# Altium schematic sheet Current Signal Measuring.SchDoc("Current Signal Measuring")
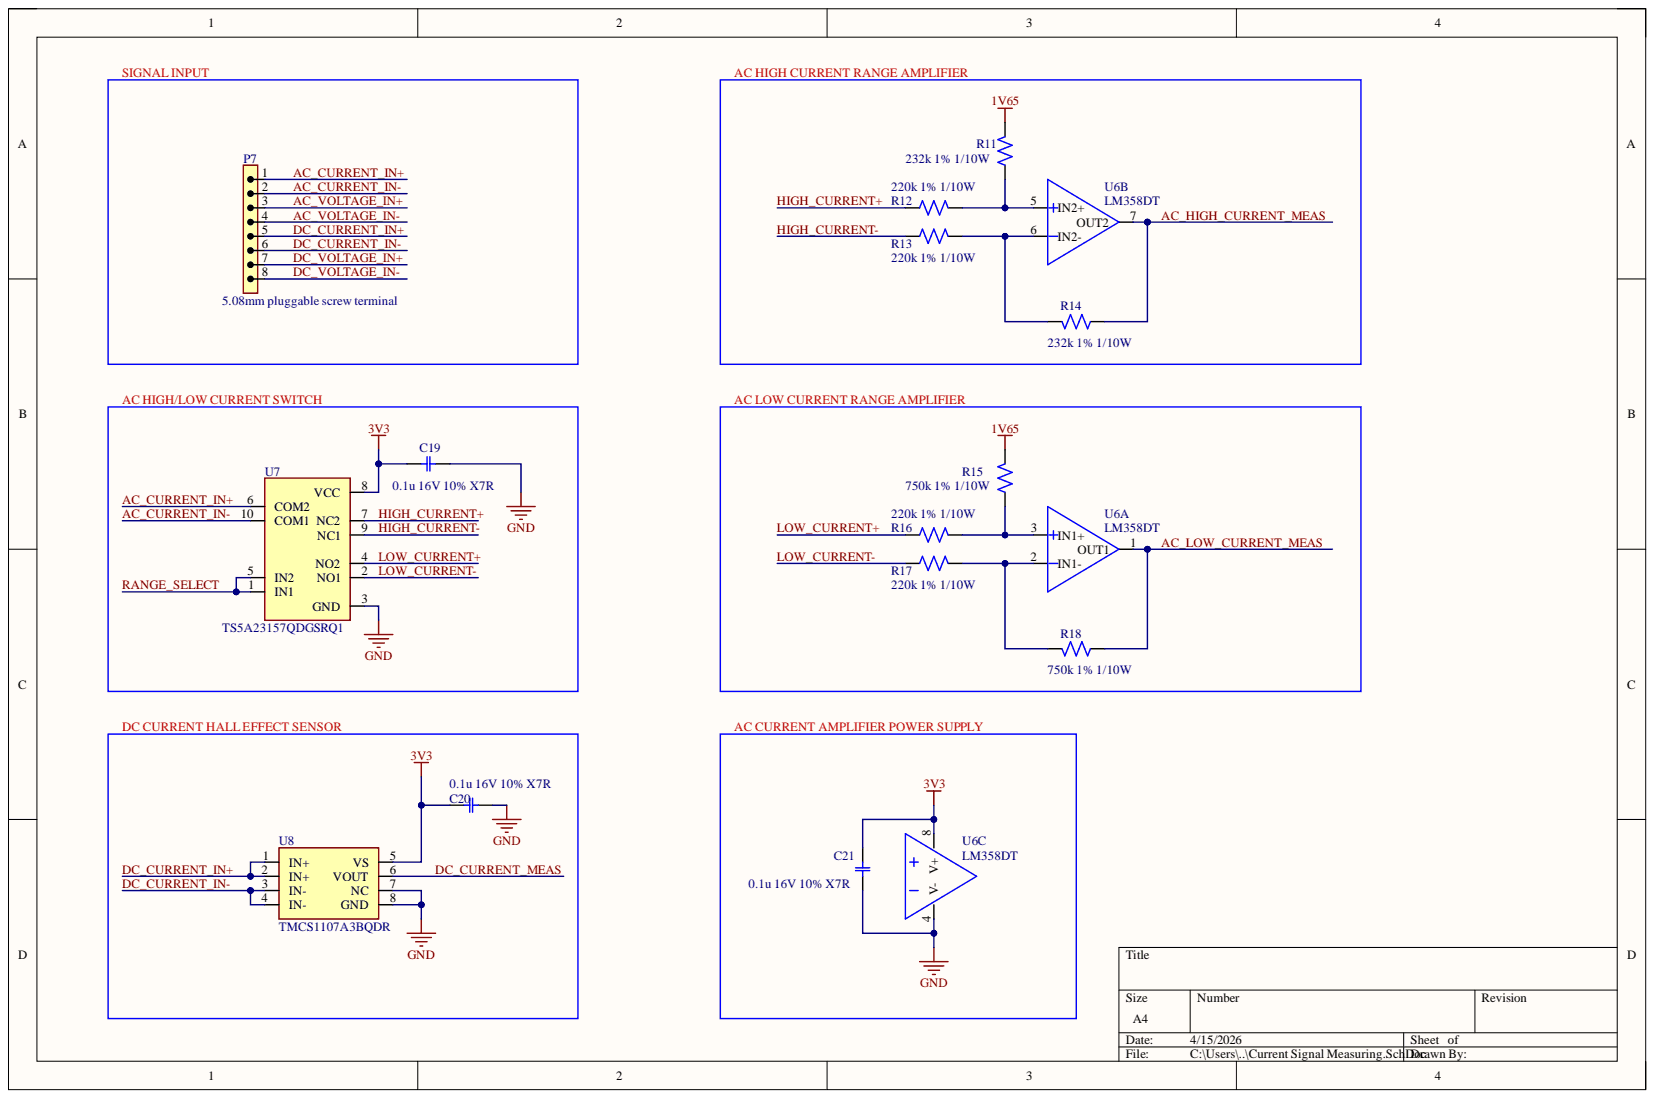
[diagram p0: Current Signal Measuring.SchDoc]

## Parts
C19  0.1u 16V 10% X7R  Dielectric: X7R  Tolerance: 10%  Voltage: 16V  [0603-C]
C20  0.1u 16V 10% X7R  Tolerance: 10%  Voltage: 16V  [0603-C]
C21  0.1u 16V 10% X7R  Dielectric: X7R  [0603-C]
P7  5.08mm pluggable screw terminal  [5.08mm pluggable screw terminal]
R11  232k 1% 1/10W  [0603-R]
R12  220k 1% 1/10W  Tolerance: 1%  [0603-R]
R13  220k 1% 1/10W  Tolerance: 1%  [0603-R]
R14  232k 1% 1/10W  Tolerance: 1%  [0603-R]
R15  750k 1% 1/10W  [0603-R]
R16  220k 1% 1/10W  Tolerance: 1%  [0603-R]
R17  220k 1% 1/10W  Tolerance: 1%  [0603-R]
R18  750k 1% 1/10W  Tolerance: 1%  [0603-R]
U6  LM358DT  [LM358DT]  (parts A,B,C)
U7  TS5A23157QDGSRQ1  [TS5A23157QDGSRQ1]
U8  TMCS1107A3BQDR  [TMCS1107A3BQDR]

## Nets
1V65: R11.1 R15.1
3V3: C19.2 C20.1 C21.1 U6.8(V+) U7.8(VCC) U8.5(VS)
GND: C19.1 C20.2 C21.2 U6.4(V-) U7.3(GND) U8.7(NC) U8.8(GND)
AC_CURRENT_IN+: P7.1 U7.6(COM2)
AC_CURRENT_IN-: P7.2 U7.10(COM1)
AC_HIGH_CURRENT_MEAS: R14.2 U6.7(OUT2)
AC_LOW_CURRENT_MEAS: R18.2 U6.1(OUT1)
AC_VOLTAGE_IN+: P7.3
AC_VOLTAGE_IN-: P7.4
DC_CURRENT_IN+: P7.5 U8.1(IN+) U8.2(IN+)
DC_CURRENT_IN-: P7.6 U8.3(IN-) U8.4(IN-)
DC_CURRENT_MEAS: U8.6(VOUT)
DC_VOLTAGE_IN+: P7.7
DC_VOLTAGE_IN-: P7.8
HIGH_CURRENT+: R12.2 U7.7(NC2)
HIGH_CURRENT-: R13.2 U7.9(NC1)
LOW_CURRENT+: R16.2 U7.4(NO2)
LOW_CURRENT-: R17.2 U7.2(NO1)
RANGE_SELECT: U7.1(IN1) U7.5(IN2)
NetR11_2: R11.2 R12.1 U6.5(IN2+)
NetR13_1: R13.1 R14.1 U6.6(IN2-)
NetR15_2: R15.2 R16.1 U6.3(IN1+)
NetR17_1: R17.1 R18.1 U6.2(IN1-)

## Library data of the parts
C19-C21 = CC0603KRX7R7BB104: 0603 0.1 uF 16 V ±10 % Tolerance X7R SMT Multilayer Ceramic Capacitor; Manufacturer: Yageo; Insulation Resistance: 100GR; Value: 100nF; Case Code (Imperial): 0603; Case Code (Metric): 1608; Case/Package: 0603; Category: Ceramic Capacitors; Component Kind: Standard; ComponentLink1Description: https://datasheet.ciiva.com/11786/0900766b80d84f1d-11786077.pdf?src-supplier=Rs; ComponentLink1URL: https://datasheet.ciiva.com/11786/0900766b80d84f1d-11786077.pdf?src-supplier=Rs; ComponentLink2Description: https://datasheet.ciiva.com/144/mlccorderingcode-144182.pdf?src-supplier=Digikey; ComponentLink2URL: https://datasheet.ciiva.com/144/mlccorderingcode-144182.pdf?src-supplier=Digikey; ComponentLink3Description: https://datasheet.ciiva.com/144/mlccorderingcode-144183.pdf?src-supplier=Digikey; ComponentLink3URL: https://datasheet.ciiva.com/144/mlccorderingcode-144183.pdf?src-supplier=Digikey; ComponentLink4Description: https://datasheet.ciiva.com/144/mlccorderingcode-144184.pdf?src-supplier=Digikey; ComponentLink4URL: https://datasheet.ciiva.com/144/mlccorderingcode-144184.pdf?src-supplier=Digikey; ComponentLink5Description: https://datasheet.ciiva.com/7978/1489800-7978141.pdf?src-supplier=Element14; ComponentLink5URL: https://datasheet.ciiva.com/7978/1489800-7978141.pdf?src-supplier=Element14; ComponentLink6Description: https://datasheet.ciiva.com/11464/upy-gphc-x7r-6-3v-to-50v-8-11464735.pdf?src-supplier=Avnet; ComponentLink6URL: https://datasheet.ciiva.com/11464/upy-gphc-x7r-6-3v-to-50v-8-11464735.pdf?src-supplier=Avnet; ComponentLink7Description: https://datasheet.ciiva.com/28450/1489800-28450552.pdf?src-supplier=Element14; ComponentLink7URL: https://datasheet.ciiva.com/28450/1489800-28450552.pdf?src-supplier=Element14; ComponentLink8Description: https://datasheet.ciiva.com/46430/1734573-46430156.pdf?src-supplier=Element14; ComponentLink8URL: https://datasheet.ciiva.com/46430/1734573-46430156.pdf?src-supplier=Element14; ComponentLink9Description: https://datasheet.ciiva.com/8033/1734573-8033797.pdf?src-supplier=Element14; ComponentLink9URL: https://datasheet.ciiva.com/8033/1734573-8033797.pdf?src-supplier=Element14; ComponentLink10Description: https://datasheet.ciiva.com/8033/70765-8033799.pdf?src-supplier=Element14; ComponentLink10URL: https://datasheet.ciiva.com/8033/70765-8033799.pdf?src-supplier=Element14; ComponentLink11Description: https://datasheet.ciiva.com/15165/getdatasheetpartid-63554-15165387.pdf?src-supplier=Verical; ComponentLink11URL: https://datasheet.ciiva.com/15165/getdatasheetpartid-63554-15165387.pdf?src-supplier=Verical; ComponentLink12Description: https://datasheet.ciiva.com/13395/upy-gphc-x7r-6-3v-to-50v-8-13395674.pdf?src-supplier=Mouser; ComponentLink12URL: https://datasheet.ciiva.com/13395/upy-gphc-x7r-6-3v-to-50v-8-13395674.pdf?src-supplier=Mouser; Depth: 0.8mm; Halogen Free: Halogen Free; Height: 0.8mm; Lead Free: Lead Free; Length: 1.6mm; Manufacturer Part Number: CC0603KRX7R7BB104; Material: Ceramic; Max Operating Temperature: 125°C; Min Operating Temperature: -55°C; Mounting Technology: Surface Mount; Package Quantity: 1; Packaging: Tape and Reel; Pins: 2; Pricing: 1=0.08, 10=0.014, 50=0.012, 100=0.0096, 500=0.0072, 1000=0.0064 (USD); Radiation Hardening: No; Reach SVHC Compliant: No; RoHS: Yes; RoHS Compliant: Yes; Series: CC; Stock: 7111175; Supplier 1: Digikey; Supplier Part Number 1: 311-1088-1-ND; Termination: SMD/SMT; Thickness: 0.035inch; Voltage Rating: 16V; Voltage Rating (DC): 16V; Width: 0.031inch; Library Name: tpmania.SchLib
  C20: Dielectric: X7R
  C21: Tolerance: 10%; Voltage: 16V
R11 R14 = RC0603FR-07232KL: 100mW Thick Film Resistors 75V ±100ppm/℃ ±1% 232kΩ 0603 Chip Resistor - Surface Mount ROHS; Manufacturer: Yageo Group; Temperature Coefficient: 100ppm/°C; Voltage Rating (DC): 50V; Case Code (Imperial): 0603; Case/Package: 0603; Category: Chip SMD Resistors; China RoHS: Non-Compliant; Component Kind: Standard; ComponentLink1Description: https://datasheet.octopart.com:443/RC0603FR-07232KL-Yageo-datasheet-175887523.pdf?src-supplier=IHS; ComponentLink1URL: https://datasheet.octopart.com:443/RC0603FR-07232KL-Yageo-datasheet-175887523.pdf?src-supplier=IHS; ComponentLink2Description: https://datasheet.octopart.com:443/RC0603FR-07232KL-Yageo-datasheet-103092701.pdf?src-supplier=Upverter; ComponentLink2URL: https://datasheet.octopart.com:443/RC0603FR-07232KL-Yageo-datasheet-103092701.pdf?src-supplier=Upverter; ComponentLink3Description: https://datasheet.octopart.com:443/RC0603FR-07232KL-Yageo-Group-datasheet-189192902.pdf?src-supplier=TME; ComponentLink3URL: https://datasheet.octopart.com:443/RC0603FR-07232KL-Yageo-Group-datasheet-189192902.pdf?src-supplier=TME; ComponentLink4Description: https://datasheet.octopart.com:443/RC0603FR-07232KL-Yageo-Group-datasheet-176638170.pdf?src-supplier=Future+Electronics; ComponentLink4URL: https://datasheet.octopart.com:443/RC0603FR-07232KL-Yageo-Group-datasheet-176638170.pdf?src-supplier=Future+Electronics; ComponentLink5Description: https://datasheet.octopart.com:443/RC0603FR-07232KL-Yageo-datasheet-164759695.pdf?src-supplier=Future+Electronics; ComponentLink5URL: https://datasheet.octopart.com:443/RC0603FR-07232KL-Yageo-datasheet-164759695.pdf?src-supplier=Future+Electronics; ComponentLink6Description: https://datasheet.octopart.com:443/RC0603FR-07232KL-Yageo-datasheet-137700087.pdf?src-supplier=Future+Electronics; ComponentLink6URL: https://datasheet.octopart.com:443/RC0603FR-07232KL-Yageo-datasheet-137700087.pdf?src-supplier=Future+Electronics; ComponentLink7Description: https://datasheet.octopart.com:443/RC0603FR-07232KL-Yageo-datasheet-17842380.pdf?src-supplier=Future+Electronics; ComponentLink7URL: https://datasheet.octopart.com:443/RC0603FR-07232KL-Yageo-datasheet-17842380.pdf?src-supplier=Future+Electronics; ComponentLink8Description: https://datasheet.octopart.com:443/RC0603FR-07232KL-Yageo-datasheet-15599030.pdf?src-supplier=Future+Electronics; ComponentLink8URL: https://datasheet.octopart.com:443/RC0603FR-07232KL-Yageo-datasheet-15599030.pdf?src-supplier=Future+Electronics; ComponentLink9Description: https://datasheet.octopart.com:443/RC0603FR-07232KL-Yageo-datasheet-12596169.pdf?src-supplier=Future+Electronics; ComponentLink9URL: https://datasheet.octopart.com:443/RC0603FR-07232KL-Yageo-datasheet-12596169.pdf?src-supplier=Future+Electronics; ComponentLink10Description: https://datasheet.octopart.com:443/RC0603FR-07232KL-Yageo-datasheet-8328172.pdf?src-supplier=_legacy+Avnet; ComponentLink10URL: https://datasheet.octopart.com:443/RC0603FR-07232KL-Yageo-datasheet-8328172.pdf?src-supplier=_legacy+Avnet; ComponentLink11Description: https://datasheet.octopart.com/RC0603FR-07232KL-Yageo-datasheet-73766026.pdf; ComponentLink11URL: https://datasheet.octopart.com/RC0603FR-07232KL-Yageo-datasheet-73766026.pdf; ComponentLink12Description: https://datasheet.octopart.com:443/RC0603FR-07232KL-Yageo-datasheet-118562.pdf?src-supplier=DigiKey; ComponentLink12URL: https://datasheet.octopart.com:443/RC0603FR-07232KL-Yageo-datasheet-118562.pdf?src-supplier=DigiKey; ComponentLink13Description: http://sigma.octopart.com:80/conflict_mineral_statement/Yageo-company-3.pdf?src-supplier=Compliance+Statements; ComponentLink13URL: http://sigma.octopart.com:80/conflict_mineral_statement/Yageo-company-3.pdf?src-supplier=Compliance+Statements; ComponentLink14Description: http://sigma.octopart.com:80/rohs_statement/Yageo-company-2.pdf?src-supplier=Compliance+Statements; ComponentLink14URL: http://sigma.octopart.com:80/rohs_statement/Yageo-company-2.pdf?src-supplier=Compliance+Statements; Composition: Thick Film; Contact Plating: Tin; Country of Origin: Mainland China, Taiwan; Depth: 800µm; Export Control Classification Number (ECCN) Code: EAR99; Features: Moisture Resistant; Harmonized Tariff Schedule (HTS) Code: 8533.21.00.30; Height: 450µm; Introduction Date: 2004-09-22; Lead Free: Lead Free; Length: 1.6mm; Library Name: ENGG3800 schematic library.SchLib; Lifecycle Status: Production; Manufacturer Part Number: RC0603FR-07232KL; Max Operating Temperature: 155°C; Max Power Dissipation: 100mW; Military Standard: Not; Min Operating Temperature: -55°C; Mounting Technology: Surface Mount; Number of Terminals: 2; Number of Terminations: 2; Packaging: Tape & Reel; Power Dissipation: 100mW; Power Rating: 100mW; Pricing: 1=0.103, 25=0.007, 100=0.006 (USD); REACH SVHC: Yes; RoHS: Compliant; Schedule B: 8533210045, 8533210045|8533210045, 8533210045|8533210045|8533210045, 8533210045|8533210045|8533210045|8533210045; Stock: 2291; Supplier 1: Digikey; Supplier Part Number 1: 311-232KHRCT-ND; Termination: SMD/SMT; Value: 232kΩ; Voltage Rating: 75V; Width: 800µm; Working Voltage: 75V
  R11: Tolerance: 1%
R12 R13 R16 R17 = RMCF0603FT220K: Res Thick Film 0603 220K Ohm 1% 0.1W(1/10W) ±100ppm/C Pad SMD Automotive T/R; Manufacturer: Stackpole Electronics; Max Power Dissipation: 100mW; Temperature Coefficient: 100ppm/°C; Value: 220kΩ; Voltage Rating: 75V; Case Code (Imperial): 0603; Case Code (Metric): 1608; Case/Package: 0603; Category: Chip SMD Resistors; China RoHS: Non-Compliant; Component Kind: Standard; ComponentLink1Description: https://datasheet.octopart.com:443/RMCF0603FT220K-Stackpole-Electronics-datasheet-182148065.pdf?src-supplier=IHS; ComponentLink1URL: https://datasheet.octopart.com:443/RMCF0603FT220K-Stackpole-Electronics-datasheet-182148065.pdf?src-supplier=IHS; ComponentLink2Description: https://datasheet.octopart.com:443/RMCF0603FT220K-Stackpole-Electronics-datasheet-28504820.pdf?src-supplier=Upverter; ComponentLink2URL: https://datasheet.octopart.com:443/RMCF0603FT220K-Stackpole-Electronics-datasheet-28504820.pdf?src-supplier=Upverter; ComponentLink3Description: https://datasheet.octopart.com:443/RMCF0603FT220K-Stackpole-Electronics-datasheet-141193804.pdf?src-supplier=Stackpole+Electronics; ComponentLink3URL: https://datasheet.octopart.com:443/RMCF0603FT220K-Stackpole-Electronics-datasheet-141193804.pdf?src-supplier=Stackpole+Electronics; ComponentLink4Description: https://datasheet.octopart.com:443/RMCF0603FT220K-Stackpole-Electronics-datasheet-62091608.pdf?src-supplier=Stackpole+Electronics; ComponentLink4URL: https://datasheet.octopart.com:443/RMCF0603FT220K-Stackpole-Electronics-datasheet-62091608.pdf?src-supplier=Stackpole+Electronics; ComponentLink5Description: https://datasheet.octopart.com:443/RMCF0603FT220K-Stackpole-Electronics-datasheet-12534581.pdf?src-supplier=Stackpole+Electronics; ComponentLink5URL: https://datasheet.octopart.com:443/RMCF0603FT220K-Stackpole-Electronics-datasheet-12534581.pdf?src-supplier=Stackpole+Electronics; ComponentLink6Description: https://datasheet.octopart.com:443/RMCF0603FT220K-Stackpole-Electronics-datasheet-10788263.pdf?src-supplier=Stackpole+Electronics; ComponentLink6URL: https://datasheet.octopart.com:443/RMCF0603FT220K-Stackpole-Electronics-datasheet-10788263.pdf?src-supplier=Stackpole+Electronics; ComponentLink7Description: http://sigma.octopart.com:80/15940719/materials_sheet/Stackpole-Electronics-RMCF0603FT220K.pdf?src-supplier=Compliance+Statements; ComponentLink7URL: http://sigma.octopart.com:80/15940719/materials_sheet/Stackpole-Electronics-RMCF0603FT220K.pdf?src-supplier=Compliance+Statements; ComponentLink8Description: http://sigma.octopart.com:80/141158211/reach_statement/Stackpole-Electronics-RMCF0603FT220K.pdf?src-supplier=Compliance+Statements; ComponentLink8URL: http://sigma.octopart.com:80/141158211/reach_statement/Stackpole-Electronics-RMCF0603FT220K.pdf?src-supplier=Compliance+Statements; ComponentLink9Description: http://sigma.octopart.com:80/141158212/rohs_statement/Stackpole-Electronics-RMCF0603FT220K.pdf?src-supplier=Compliance+Statements; ComponentLink9URL: http://sigma.octopart.com:80/141158212/rohs_statement/Stackpole-Electronics-RMCF0603FT220K.pdf?src-supplier=Compliance+Statements; Composition: Thick Film; Contact Plating: Tin; Depth: 800µm; Features: Automotive AEC-Q200; Height: 450µm; Lead Free: Lead Free; Length: 1.55mm; Library Name: ENGG3800 schematic library.SchLib; Lifecycle Status: Production; Manufacturer Part Number: RMCF0603FT220K; Max Operating Temperature: 155°C; Military Standard: Not; Min Operating Temperature: -55°C; Mounting Technology: Surface Mount; Number of Terminals: 2; Number of Terminations: 2; Packaging: Tape & Reel (TR); Power Dissipation: 100mW; Power Rating: 100mW; Pricing: 5000=0.0019, 10000=0.00188, 20000=0.00185, 40000=0.00182, 80000=0.00179 (USD); Radiation Hardening: No; REACH SVHC: Yes; RoHS: Compliant; Schedule B: 8533210045, 8533210045|8533210045, 8533210045|8533210045|8533210045, 8533210045|8533210045|8533210045|8533210045; Stock: 385000; Supplier 1: Digikey; Supplier Part Number 1: RMCF0603FT220KCT-ND; Width: 800µm; Working Voltage: 75V
R15 R18 = RC0603FR-07750KL: 100mW Thick Film Resistors 75V ±100ppm/℃ ±1% 750kΩ 0603 Chip Resistor - Surface Mount ROHS; Manufacturer: Yageo Group; Temperature Coefficient: 100ppm/°C; Voltage Rating (DC): 50V; Case Code (Imperial): 0603; Case/Package: 0603; Category: Chip SMD Resistors; China RoHS: Non-Compliant; Component Kind: Standard; ComponentLink1Description: https://datasheet.octopart.com:443/RC0603FR-07750KL-Yageo-datasheet-175887523.pdf?src-supplier=Newark; ComponentLink1URL: https://datasheet.octopart.com:443/RC0603FR-07750KL-Yageo-datasheet-175887523.pdf?src-supplier=Newark; ComponentLink2Description: https://datasheet.octopart.com:443/RC0603FR-07750KL-Yageo-datasheet-103092701.pdf?src-supplier=Upverter; ComponentLink2URL: https://datasheet.octopart.com:443/RC0603FR-07750KL-Yageo-datasheet-103092701.pdf?src-supplier=Upverter; ComponentLink3Description: https://datasheet.octopart.com:443/RC0603FR-07750KL-Yageo-Group-datasheet-176638170.pdf?src-supplier=Future+Electronics; ComponentLink3URL: https://datasheet.octopart.com:443/RC0603FR-07750KL-Yageo-Group-datasheet-176638170.pdf?src-supplier=Future+Electronics; ComponentLink4Description: https://datasheet.octopart.com:443/RC0603FR-07750KL-Yageo-datasheet-164759695.pdf?src-supplier=Future+Electronics; ComponentLink4URL: https://datasheet.octopart.com:443/RC0603FR-07750KL-Yageo-datasheet-164759695.pdf?src-supplier=Future+Electronics; ComponentLink5Description: https://datasheet.octopart.com:443/RC0603FR-07750KL-Yageo-datasheet-137700087.pdf?src-supplier=Future+Electronics; ComponentLink5URL: https://datasheet.octopart.com:443/RC0603FR-07750KL-Yageo-datasheet-137700087.pdf?src-supplier=Future+Electronics; ComponentLink6Description: https://datasheet.octopart.com:443/RC0603FR-07750KL-Yageo-datasheet-15599030.pdf?src-supplier=Future+Electronics; ComponentLink6URL: https://datasheet.octopart.com:443/RC0603FR-07750KL-Yageo-datasheet-15599030.pdf?src-supplier=Future+Electronics; ComponentLink7Description: https://datasheet.octopart.com:443/RC0603FR-07750KL-Yageo-datasheet-12596169.pdf?src-supplier=Future+Electronics; ComponentLink7URL: https://datasheet.octopart.com:443/RC0603FR-07750KL-Yageo-datasheet-12596169.pdf?src-supplier=Future+Electronics; ComponentLink8Description: https://datasheet.octopart.com:443/RC0603FR-07750KL-Yageo-datasheet-8328172.pdf?src-supplier=Future+Electronics; ComponentLink8URL: https://datasheet.octopart.com:443/RC0603FR-07750KL-Yageo-datasheet-8328172.pdf?src-supplier=Future+Electronics; ComponentLink9Description: https://datasheet.octopart.com:443/RC0603FR-07750KL-Yageo-datasheet-148775077.pdf?src-supplier=TME; ComponentLink9URL: https://datasheet.octopart.com:443/RC0603FR-07750KL-Yageo-datasheet-148775077.pdf?src-supplier=TME; ComponentLink10Description: https://datasheet.octopart.com:443/RC0603FR-07750KL-Yageo-datasheet-79472498.pdf?src-supplier=Megastar; ComponentLink10URL: https://datasheet.octopart.com:443/RC0603FR-07750KL-Yageo-datasheet-79472498.pdf?src-supplier=Megastar; ComponentLink11Description: https://datasheet.octopart.com/RC0603FR-07750KL-Yageo-datasheet-73766026.pdf; ComponentLink11URL: https://datasheet.octopart.com/RC0603FR-07750KL-Yageo-datasheet-73766026.pdf; ComponentLink12Description: https://datasheet.octopart.com:443/RC0603FR-07750KL-Yageo-datasheet-118562.pdf?src-supplier=DigiKey; ComponentLink12URL: https://datasheet.octopart.com:443/RC0603FR-07750KL-Yageo-datasheet-118562.pdf?src-supplier=DigiKey; ComponentLink13Description: http://sigma.octopart.com:80/conflict_mineral_statement/Yageo-company-3.pdf?src-supplier=Compliance+Statements; ComponentLink13URL: http://sigma.octopart.com:80/conflict_mineral_statement/Yageo-company-3.pdf?src-supplier=Compliance+Statements; ComponentLink14Description: http://sigma.octopart.com:80/rohs_statement/Yageo-company-2.pdf?src-supplier=Compliance+Statements; ComponentLink14URL: http://sigma.octopart.com:80/rohs_statement/Yageo-company-2.pdf?src-supplier=Compliance+Statements; Composition: Thick Film; Depth: 800µm; Features: Moisture Resistant; Height: 450µm; Lead Free: Lead Free; Length: 1.6mm; Library Name: ENGG3800 schematic library.SchLib; Lifecycle Status: Production; Manufacturer Part Number: RC0603FR-07750KL; Max Operating Temperature: 155°C; Max Power Dissipation: 100mW; Military Standard: Not; Min Operating Temperature: -55°C; Mounting Technology: Surface Mount; Number of Terminals: 2; Number of Terminations: 2; Packaging: Tape & Reel; Power Dissipation: 100mW; Power Rating: 100mW; Pricing: 1=0.103, 25=0.008, 50=0.007, 100=0.006 (USD); REACH SVHC: Yes; RoHS: Compliant; Schedule B: 8533210045, 8533210045|8533210045, 8533210045|8533210045|8533210045, 8533210045|8533210045|8533210045|8533210045; Stock: 11686; Supplier 1: Digikey; Supplier Part Number 1: 311-750KHRCT-ND; Termination: SMD/SMT; Value: 750kΩ; Voltage Rating: 75V; Weight: 2.012816mg; Width: 800µm; Working Voltage: 75V
  R15: Tolerance: 1%
U6 = LM358DT: Integrated Circuit Operational Amplifier; CH: 2; 1.1MHz; 3-32V; 0+70 deg.C; SMD; SO8; Manufacturer: STMicroelectronics; Common Mode Rejection Ratio: 60dB; Dual Supply Voltage: 9V; Input Bias Current: 150nA; Input Offset Voltage (Vos): 9mV; Max Dual Supply Voltage: 15V; Max Junction Temperature (Tj): 150°C; Max Output current: 60mA; Max Supply Current: 2mA; Max Supply Voltage: 28.5V; Min Dual Supply Voltage: 1.5V; Min Input Voltage: 0V; Min Supply Voltage: 3V; Nominal Supply Current: 350µA; Number of Amplifiers: 2; Operating Supply Current: 700µA; Operating Supply Voltage: 5V; Slew Rate: 0.3V/µs; Voltage Gain: 100dB; Bandwidth: 1.1MHz; Case/Package: SOP; Category: Amplifiers - Op Amps, Buffer, Instrumentation; China RoHS: Compliant; Component Kind: Standard; ComponentLink1Description: https://datasheet.octopart.com:443/LM358DT-STMicroelectronics-datasheet-116606904.pdf?src-supplier=ODG+(Origin+Data+Global); ComponentLink1URL: https://datasheet.octopart.com:443/LM358DT-STMicroelectronics-datasheet-116606904.pdf?src-supplier=ODG+(Origin+Data+Global); ComponentLink2Description: https://datasheet.octopart.com:443/LM358DT-STMicroelectronics-datasheet-61210252.pdf?src-supplier=element14+APAC; ComponentLink2URL: https://datasheet.octopart.com:443/LM358DT-STMicroelectronics-datasheet-61210252.pdf?src-supplier=element14+APAC; ComponentLink3Description: https://datasheet.octopart.com:443/LM358DT-STMicroelectronics-datasheet-11005313.pdf?src-supplier=STMicroelectronics; ComponentLink3URL: https://datasheet.octopart.com:443/LM358DT-STMicroelectronics-datasheet-11005313.pdf?src-supplier=STMicroelectronics; ComponentLink4Description: https://datasheet.octopart.com:443/LM358DT-STMicroelectronics-datasheet-10410245.pdf?src-supplier=Future+Electronics; ComponentLink4URL: https://datasheet.octopart.com:443/LM358DT-STMicroelectronics-datasheet-10410245.pdf?src-supplier=Future+Electronics; ComponentLink5Description: https://datasheet.octopart.com:443/LM358DT-STMicroelectronics-datasheet-529748.pdf?src-supplier=element14; ComponentLink5URL: https://datasheet.octopart.com:443/LM358DT-STMicroelectronics-datasheet-529748.pdf?src-supplier=element14; ComponentLink6Description: https://datasheet.octopart.com:443/LM358DT-STMicroelectronics-datasheet-109535.pdf?src-supplier=element14; ComponentLink6URL: https://datasheet.octopart.com:443/LM358DT-STMicroelectronics-datasheet-109535.pdf?src-supplier=element14; ComponentLink7Description: https://datasheet.octopart.com:443/LM358DT-STMicroelectronics-datasheet-81668593.pdf?src-supplier=SHENGYU+ELECTRONICS; ComponentLink7URL: https://datasheet.octopart.com:443/LM358DT-STMicroelectronics-datasheet-81668593.pdf?src-supplier=SHENGYU+ELECTRONICS; ComponentLink8Description: https://datasheet.octopart.com:443/LM358DT-STMicroelectronics-datasheet-36993016.pdf?src-supplier=TME; ComponentLink8URL: https://datasheet.octopart.com:443/LM358DT-STMicroelectronics-datasheet-36993016.pdf?src-supplier=TME; ComponentLink9Description: https://datasheet.octopart.com:443/LM358DT-STMicroelectronics-datasheet-57.pdf?src-supplier=Newark; ComponentLink9URL: https://datasheet.octopart.com:443/LM358DT-STMicroelectronics-datasheet-57.pdf?src-supplier=Newark; ComponentLink10Description: https://datasheet.octopart.com:443/LM358DT-STMicroelectronics-datasheet-15994790.pdf?src-supplier=Newark; ComponentLink10URL: https://datasheet.octopart.com:443/LM358DT-STMicroelectronics-datasheet-15994790.pdf?src-supplier=Newark; ComponentLink11Description: https://datasheet.octopart.com:443/LM358DT-STMicroelectronics-datasheet-14137276.pdf?src-supplier=iiiC; ComponentLink11URL: https://datasheet.octopart.com:443/LM358DT-STMicroelectronics-datasheet-14137276.pdf?src-supplier=iiiC; ComponentLink12Description: https://datasheet.octopart.com:443/LM358DT-STMicroelectronics-datasheet-9802834.pdf?src-supplier=RS+(Formerly+Allied+Electronics); ComponentLink12URL: https://datasheet.octopart.com:443/LM358DT-STMicroelectronics-datasheet-9802834.pdf?src-supplier=RS+(Formerly+Allied+Electronics); ComponentLink13Description: https://datasheet.octopart.com:443/LM358DT-STMicroelectronics-datasheet-5352292.pdf?src-supplier=Farnell; ComponentLink13URL: https://datasheet.octopart.com:443/LM358DT-STMicroelectronics-datasheet-5352292.pdf?src-supplier=Farnell; Contact Plating: Tin; Country of Origin: Mainland China; Export Control Classification Number (ECCN) Code: EAR99; Gain Bandwidth Product: 1.1MHz; Harmonized Tariff Schedule (HTS) Code: 8542.33.00.01; Height: 1.75mm; Height - Seated (Max): 1.75mm; Introduction Date: 1980-01-04; Lead Free: Lead Free; Length: 4.9mm; Library Name: ENGG3800 schematic library.SchLib; Lifecycle Status: Production; Manufacturer Part Number: LM358DT; Max Operating Temperature: 70°C; Min Operating Temperature: 0°C; Mounting Technology: Surface Mount; Number of Channels: 2; Number of Circuits: 2; Number of Elements: 2; Number of Terminals: 2; Output Current: 60mA; Output Current per Channel: 60mA; Packaging: Tape and Reel; Pins: 8; Pricing: 2500=0.0538, 5000=0.05346, 10000=0.05247, 20000=0.05152, 40000=0.0506 (USD); Radiation Hardening: No; REACH SVHC: Yes; RoHS: Compliant; Schedule B: 8542330000, 8542330000|8542330000|8542330000|8542330000|8542330000|8542330000|8542330000|8542330000|8542330000|8542330000|8542330000|8542330000|8542330000|8542330000|8542330000|8542330000|8542330000|8542330000|8542330000|8542330000|8542330000|8542330000|8542330000|85; Stock: 97500; Supplier 1: Digikey; Supplier Part Number 1: 497-1591-1-ND; Temperature Grade: Commercial; Terminal Pitch: 1.27mm; Unity Gain Bandwidth Product: 1.1MHz; Weight: 4.535924g; Width: 3.9mm
U7 = TS5A23157QDGSRQ1: Automotive 5-V, 2: 1 (SPDT), 2-channel analog switch 10-VSSOP -40 to 125; Manufacturer: Texas Instruments; Manufacturer Lifecycle Status: ACTIVE; -3db Bandwidth: 220MHz; Drain to Source Resistance: 15Ω; High Level Output Current: 50mA; Max Supply Current: 1µA; Max Supply Voltage: 5.5V; Min Supply Voltage: 1.65V; Number of Channels: 2; Number of Outputs: 4; Polarity: Non-Inverting; Supply Type: Single; Turn-Off Delay Time: 3.8ns; Turn-On Delay Time: 57ns; Ambient Temperature Range High: 125°C; Bandwidth: 220MHz; Case/Package: VSSOP; Category: Analog Switches and Multiplexers; Component Kind: Standard; ComponentLink1Description: https://octopart.com:443/opatz8j6/c1?t=6YZ1PmnTPIkj37n7SMi41KU_OXrygvbwysh-KHZV3QdO04vf07P6rMInUELX-LiUtAzRPKGQT_JvMPLneT9eIBN29fDZZ10qik4sgMec-Q6GAEp21w4HXnwO5StuOSALNDe0I3OwF59_wb6A1UNdlpKbmY8Xur33UpllzqyqEJfBCNEuhlTFPE7_3sAFeDb8k_baysLsZjo5WsllNZkc75ea8NW0Zz69yhqmbjUwzPgz0CVeyVEs_g4emFC0g84vbaUs_u0WJWlufSmOLijUpaLnH-EyrG3UBaX4S_DiOQi45LT66-up3whHCSR3fk4kHG8DgoDtImjX4ZpukeCQEncWW2nOZ4Avl5mlWYcHG1wpW6iaLR269OfnVA00RmD2L0qyzxNDK_l9&src-supplier=Texas+Instruments; ComponentLink1URL: https://octopart.com:443/opatz8j6/c1?t=6YZ1PmnTPIkj37n7SMi41KU_OXrygvbwysh-KHZV3QdO04vf07P6rMInUELX-LiUtAzRPKGQT_JvMPLneT9eIBN29fDZZ10qik4sgMec-Q6GAEp21w4HXnwO5StuOSALNDe0I3OwF59_wb6A1UNdlpKbmY8Xur33UpllzqyqEJfBCNEuhlTFPE7_3sAFeDb8k_baysLsZjo5WsllNZkc75ea8NW0Zz69yhqmbjUwzPgz0CVeyVEs_g4emFC0g84vbaUs_u0WJWlufSmOLijUpaLnH-EyrG3UBaX4S_DiOQi45LT66-up3whHCSR3fk4kHG8DgoDtImjX4ZpukeCQEncWW2nOZ4Avl5mlWYcHG1wpW6iaLR269OfnVA00RmD2L0qyzxNDK_l9&src-supplier=Texas+Instruments; ComponentLink2Description: https://datasheet.octopart.com:443/TS5A23157QDGSRQ1-Texas-Instruments-datasheet-14263184.pdf?src-supplier=Texas+Instruments; ComponentLink2URL: https://datasheet.octopart.com:443/TS5A23157QDGSRQ1-Texas-Instruments-datasheet-14263184.pdf?src-supplier=Texas+Instruments; ComponentLink3Description: https://sigma.octopart.com:443/49250172/technical_drawing/Texas-Instruments-TS5A23157QDGSRQ1.pdf?src-supplier=Texas+Instruments; ComponentLink3URL: https://sigma.octopart.com:443/49250172/technical_drawing/Texas-Instruments-TS5A23157QDGSRQ1.pdf?src-supplier=Texas+Instruments; ComponentLink4Description: https://datasheet.octopart.com:443/TS5A23157QDGSRQ1-Texas-Instruments-datasheet-150511284.pdf?src-supplier=Upverter; ComponentLink4URL: https://datasheet.octopart.com:443/TS5A23157QDGSRQ1-Texas-Instruments-datasheet-150511284.pdf?src-supplier=Upverter; ComponentLink5Description: https://datasheet.octopart.com:443/TS5A23157QDGSRQ1-Texas-Instruments-datasheet-15846683.pdf?src-supplier=element14+APAC; ComponentLink5URL: https://datasheet.octopart.com:443/TS5A23157QDGSRQ1-Texas-Instruments-datasheet-15846683.pdf?src-supplier=element14+APAC; ComponentLink6Description: https://datasheet.octopart.com:443/TS5A23157QDGSRQ1-Texas-Instruments-datasheet-159118349.pdf?src-supplier=LCSC; ComponentLink6URL: https://datasheet.octopart.com:443/TS5A23157QDGSRQ1-Texas-Instruments-datasheet-159118349.pdf?src-supplier=LCSC; ComponentLink7Description: https://datasheet.octopart.com:443/TS5A23157QDGSRQ1-Texas-Instruments-datasheet-164421065.pdf?src-supplier=SHENGYU+ELECTRONICS; ComponentLink7URL: https://datasheet.octopart.com:443/TS5A23157QDGSRQ1-Texas-Instruments-datasheet-164421065.pdf?src-supplier=SHENGYU+ELECTRONICS; ComponentLink8Description: http://sigma.octopart.com:80/reach_statement/Texas-Instruments-company-64.pdf?src-supplier=Compliance+Statements; ComponentLink8URL: http://sigma.octopart.com:80/reach_statement/Texas-Instruments-company-64.pdf?src-supplier=Compliance+Statements; ComponentLink9Description: http://sigma.octopart.com:80/conflict_mineral_statement/Texas-Instruments-company-63.pdf?src-supplier=Compliance+Statements; ComponentLink9URL: http://sigma.octopart.com:80/conflict_mineral_statement/Texas-Instruments-company-63.pdf?src-supplier=Compliance+Statements; Contact Plating: Gold; Height: 1.07mm; Lead Free: Lead Free; Length: 3mm; Library Name: ENGG3800 schematic library.SchLib; Lifecycle Status: Production; Low Level Output Current: 50mA; Manufacturer Part Number: TS5A23157QDGSRQ1; Max Operating Temperature: 125°C; Min Operating Temperature: -40°C; Mounting Technology: Surface Mount; Nominal Supply Current: 1µA; Number of Circuits: 2; Number of Inputs: 2; On-State Resistance: 15Ω; Operating Supply Current: 1µA; Packaging: Cut Tape; Pins: 10; Pricing: 1=0.79, 10=0.564, 25=0.5068, 100=0.4446, 250=0.41488, 500=0.39694, 1000=0.38218 (USD); Radiation Hardening: No; REACH SVHC: No; RoHS: Compliant; Schedule B: 8542390000, 8542390000|8542390000|8542390000|8542390000|8542390000; Stock: 5187; Supplier 1: DigiKey; Supplier Part Number 1: 296-35766-1-ND; Thickness: 1.02mm; Throw Configuration: SPDT; Width: 3mm
U8 = TMCS1107A3BQDR: ±420V basic isolation, 20Arms 80kHz Hall-effect current sensor with reference 8-SOIC -40 to 125; Manufacturer: Texas Instruments; Manufacturer Lifecycle Status: ACTIVE; Case/Package: SOIC; Category: Hall Effect Sensors; Component Kind: Standard; ComponentLink1Description: https://octopart.com:443/opatz8j6/c1?t=XpA-G-qd7U8SWCb-CfbJx49JAVC86448zhUAqRIUHt6iDA_Gt_g4STiYvXXftWYihCBrZwgsDVyEU3JlyGmddtpSapTF1GldstyCEQNbcoe_Jo31yRbkeGUANGxjAWKP1jLBT3COBO-aTmeUxSrb_O3XNzoQ_YOtVGFnLZFUCiEF20uQh00qpnWty3jmMMECgzZEumrI06PpKPfj_C1BvwLNAh0sTWS4PFoL7y2I33UCLAYzVx3BECNp8NT3BI3gNRqRJnLBqyruJFkLnNlEop0ROPIK1ztxX-iNA6QZl6t1kAQJBoW--BT4sUrIjpZFWszgh20UMnpNRwdOo2ASo0dsjKkK4EKCS1mfEEVX-tgAkL2fGWBPe0D1ravqyc_dZ1xseW56&src-supplier=Texas+Instruments; ComponentLink1URL: https://octopart.com:443/opatz8j6/c1?t=XpA-G-qd7U8SWCb-CfbJx49JAVC86448zhUAqRIUHt6iDA_Gt_g4STiYvXXftWYihCBrZwgsDVyEU3JlyGmddtpSapTF1GldstyCEQNbcoe_Jo31yRbkeGUANGxjAWKP1jLBT3COBO-aTmeUxSrb_O3XNzoQ_YOtVGFnLZFUCiEF20uQh00qpnWty3jmMMECgzZEumrI06PpKPfj_C1BvwLNAh0sTWS4PFoL7y2I33UCLAYzVx3BECNp8NT3BI3gNRqRJnLBqyruJFkLnNlEop0ROPIK1ztxX-iNA6QZl6t1kAQJBoW--BT4sUrIjpZFWszgh20UMnpNRwdOo2ASo0dsjKkK4EKCS1mfEEVX-tgAkL2fGWBPe0D1ravqyc_dZ1xseW56&src-supplier=Texas+Instruments; ComponentLink2Description: http://sigma.octopart.com:80/reach_statement/Texas-Instruments-company-64.pdf?src-supplier=Compliance+Statements; ComponentLink2URL: http://sigma.octopart.com:80/reach_statement/Texas-Instruments-company-64.pdf?src-supplier=Compliance+Statements; ComponentLink3Description: http://sigma.octopart.com:80/conflict_mineral_statement/Texas-Instruments-company-63.pdf?src-supplier=Compliance+Statements; ComponentLink3URL: http://sigma.octopart.com:80/conflict_mineral_statement/Texas-Instruments-company-63.pdf?src-supplier=Compliance+Statements; Height: 1.75mm; Length: 4.9mm; Library Name: ENGG3800 schematic library.SchLib; Lifecycle Status: Production; Manufacturer Part Number: TMCS1107A3BQDR; Pins: 8; Pricing: 1=2.322, 10=2.2, 25=2.011, 100=1.933, 250=1.914, 500=1.518 (USD); RoHS: Compliant; Schedule B: 8542390000; Stock: 645; Supplier 1: Digikey; Supplier Part Number 1: 296-TMCS1107A3BQDRCT-ND; Thickness: 1.58mm; Width: 3.91mm
P7 = 5.08mm pluggable screw terminal: Component Kind: Standard; Library Name: ENGG3800 schematic library.SchLib; Supplier 1: etsg
